# Altium schematic sheet ICWSHLP2_Adaptor_2x2_Connectors.SchDoc(ICWSHLP2_Adaptor_2x2_Connectors)
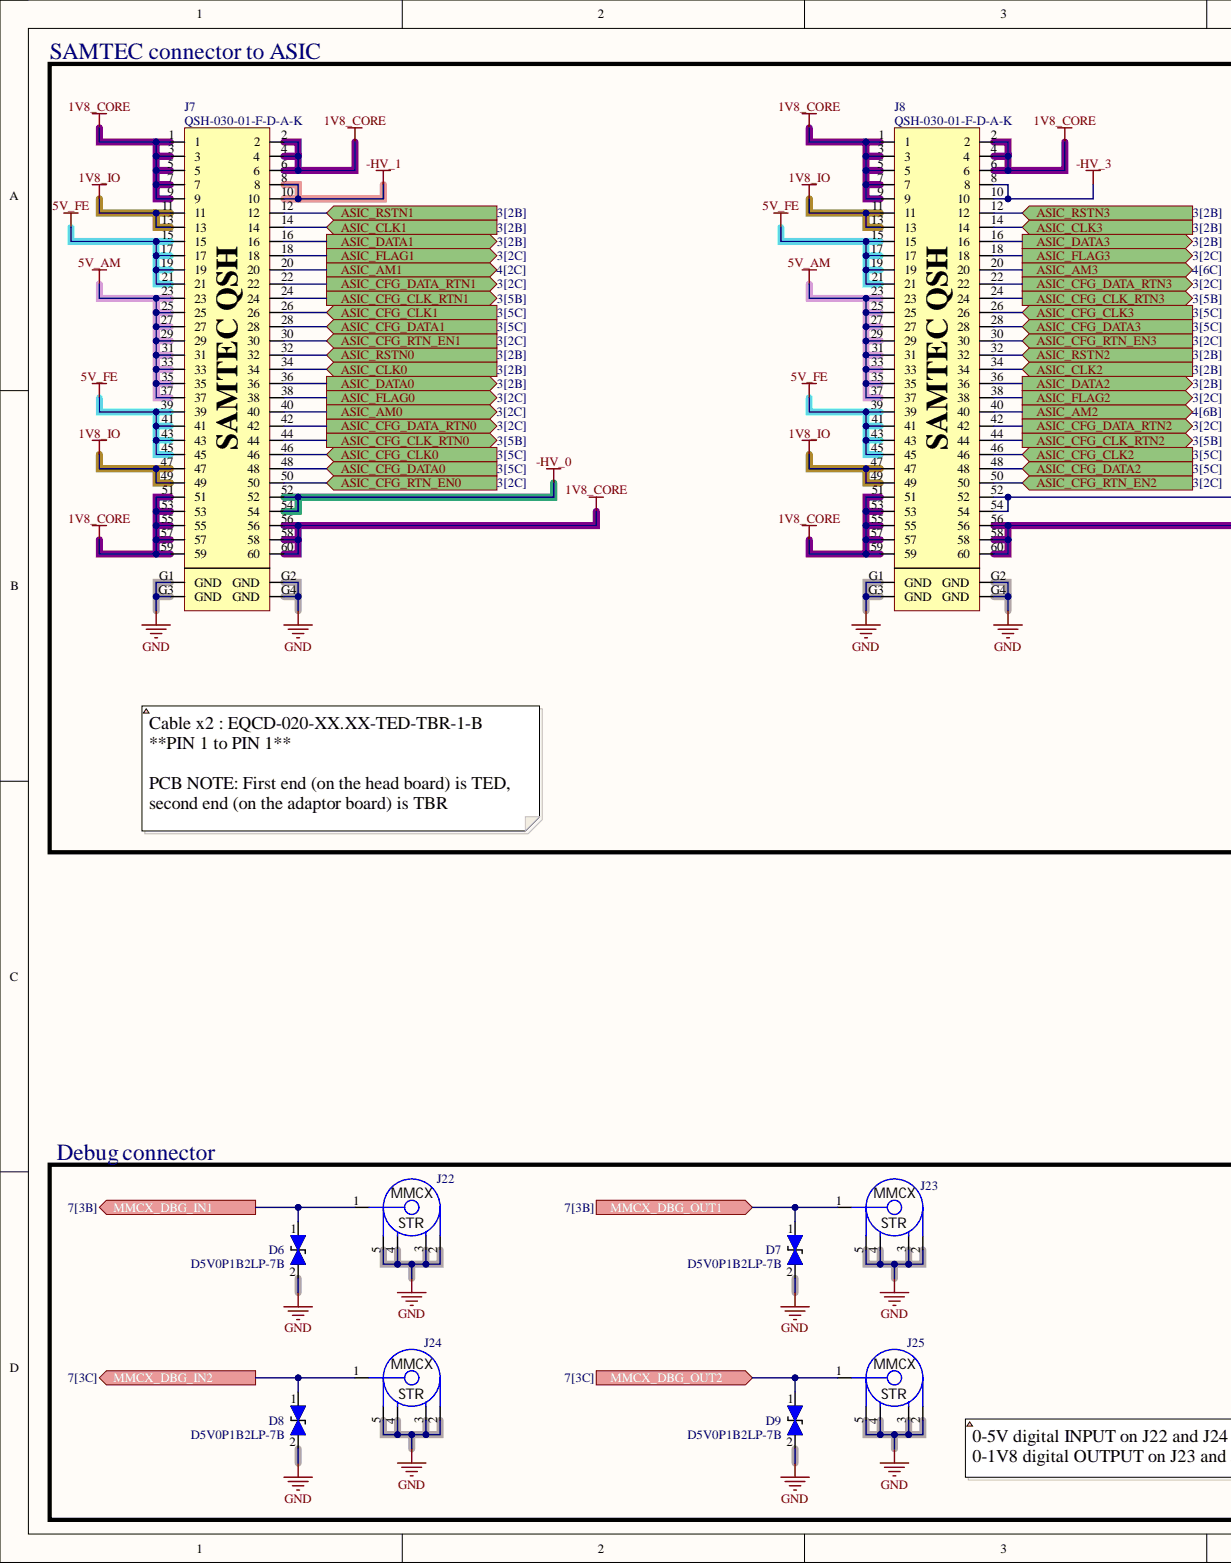
[diagram p0: ICWSHLP2_Adaptor_2x2_Connectors.SchDoc, left]
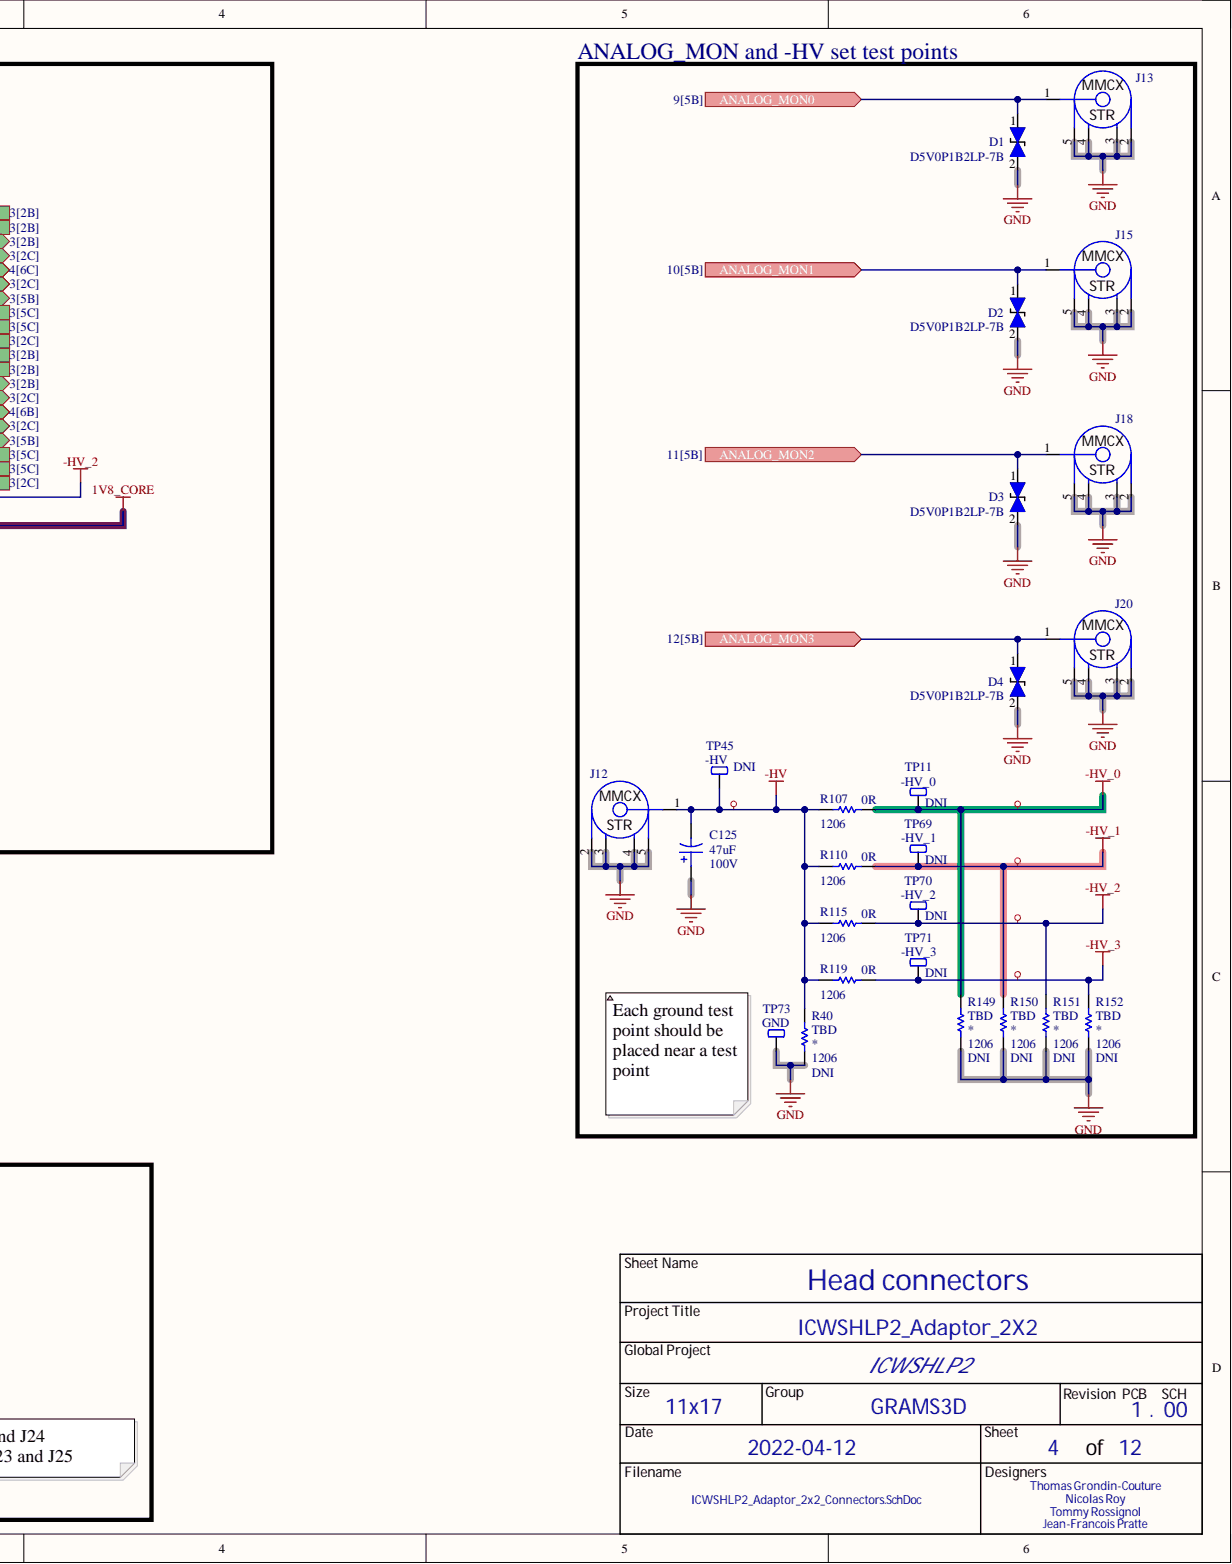
[diagram p1: ICWSHLP2_Adaptor_2x2_Connectors.SchDoc, right]

## Parts
C125  value 47uF  Voltage Rating: 100V  [CAP_ALU_870055975004]  (hidden comment: 870055975004)
D1  D5V0P1B2LP-7B  [TVS_DATA_D5V0P1B2LP-7B]
D2  D5V0P1B2LP-7B  [TVS_DATA_D5V0P1B2LP-7B]
D3  D5V0P1B2LP-7B  [TVS_DATA_D5V0P1B2LP-7B]
D4  D5V0P1B2LP-7B  [TVS_DATA_D5V0P1B2LP-7B]
D6  D5V0P1B2LP-7B  [TVS_DATA_D5V0P1B2LP-7B]
D7  D5V0P1B2LP-7B  [TVS_DATA_D5V0P1B2LP-7B]
D8  D5V0P1B2LP-7B  [TVS_DATA_D5V0P1B2LP-7B]
D9  D5V0P1B2LP-7B  [TVS_DATA_D5V0P1B2LP-7B]
J7  QSH-030-01-F-D-A-K  [CON_QSH-030-01-F-D-A-K]
J8  QSH-030-01-F-D-A-K  [CON_QSH-030-01-F-D-A-K]
J12  -HV  [CON_MMCX_STR_CONMMCX001]
J13  AM0  [CON_MMCX_STR_CONMMCX001]  (value not drawn)
J15  AM1  [CON_MMCX_STR_CONMMCX001]  (value not drawn)
J18  AM2  [CON_MMCX_STR_CONMMCX001]  (value not drawn)
J20  AM3  [CON_MMCX_STR_CONMMCX001]  (value not drawn)
J22  IN1  [CON_MMCX_STR_CONMMCX001]  (value not drawn)
J23  OUT1  [CON_MMCX_STR_CONMMCX001]  (value not drawn)
J24  IN2  [CON_MMCX_STR_CONMMCX001]  (value not drawn)
J25  OUT2  [CON_MMCX_STR_CONMMCX001]  (value not drawn)
R40  TBD  Assembly Note: DNI  Package: 1206  [RES_1206]
R107  value 0R  Package: 1206  [RES_1206]  (hidden comment: RC1206JR-070RL)
R110  value 0R  Package: 1206  [RES_1206]  (hidden comment: RC1206JR-070RL)
R115  value 0R  Package: 1206  [RES_1206]  (hidden comment: RC1206JR-070RL)
R119  value 0R  Package: 1206  [RES_1206]  (hidden comment: RC1206JR-070RL)
R149  TBD  Assembly Note: DNI  Package: 1206  [RES_1206]
R150  TBD  Assembly Note: DNI  Package: 1206  [RES_1206]
R151  TBD  Assembly Note: DNI  Package: 1206  [RES_1206]
R152  TBD  Assembly Note: DNI  Package: 1206  [RES_1206]
TP11  -HV_0  Assembly Note: DNI  [TPO_SMD_RCU-0C]
TP45  -HV  Assembly Note: DNI  [TPO_SMD_RCU-0C]
TP69  -HV_1  Assembly Note: DNI  [TPO_SMD_RCU-0C]
TP70  -HV_2  Assembly Note: DNI  [TPO_SMD_RCU-0C]
TP71  -HV_3  Assembly Note: DNI  [TPO_SMD_RCU-0C]
TP73  GND  [TPO_SMD_RCU-0C]

## Ports
ANALOG_MON0, ANALOG_MON1, ANALOG_MON2, ANALOG_MON3, ASIC_AM0, ASIC_AM1, ASIC_AM2, ASIC_AM3, ASIC_CFG_CLK0, ASIC_CFG_CLK1, ASIC_CFG_CLK2, ASIC_CFG_CLK3, ASIC_CFG_CLK_RTN0, ASIC_CFG_CLK_RTN1, ASIC_CFG_CLK_RTN2, ASIC_CFG_CLK_RTN3, ASIC_CFG_DATA0, ASIC_CFG_DATA1, ASIC_CFG_DATA2, ASIC_CFG_DATA3, ASIC_CFG_DATA_RTN0, ASIC_CFG_DATA_RTN1, ASIC_CFG_DATA_RTN2, ASIC_CFG_DATA_RTN3, ASIC_CFG_RTN_EN0, ASIC_CFG_RTN_EN1, ASIC_CFG_RTN_EN2, ASIC_CFG_RTN_EN3, ASIC_CLK0, ASIC_CLK1, ASIC_CLK2, ASIC_CLK3, ASIC_DATA0, ASIC_DATA1, ASIC_DATA2, ASIC_DATA3, ASIC_FLAG0, ASIC_FLAG1, ASIC_FLAG2, ASIC_FLAG3, ASIC_RSTN0, ASIC_RSTN1, ASIC_RSTN2, ASIC_RSTN3, MMCX_DBG_IN1, MMCX_DBG_IN2, MMCX_DBG_OUT1, MMCX_DBG_OUT2

## Nets
1V8_CORE: J7.1 J7.2 J7.3 J7.4 J7.5 J7.6 J7.7 J7.9 J7.51 J7.53 J7.55 J7.56 J7.57 J7.58 J7.59 J7.60 J8.1 J8.2 J8.3 J8.4 J8.5 J8.6 J8.7 J8.9 J8.51 J8.53 J8.55 J8.56 J8.57 J8.58 J8.59 J8.60
1V8_IO: J7.11 J7.13 J7.47 J7.49 J8.11 J8.13 J8.47 J8.49
5V_AM: J7.23 J7.25 J7.27 J7.29 J7.31 J7.33 J7.35 J7.37 J8.23 J8.25 J8.27 J8.29 J8.31 J8.33 J8.35 J8.37
5V_FE: J7.15 J7.17 J7.19 J7.21 J7.39 J7.41 J7.43 J7.45 J8.15 J8.17 J8.19 J8.21 J8.39 J8.41 J8.43 J8.45
-HV: C125.2 J12.1 R40.2 R107.1 R110.1 R115.1 R119.1 TP45.1
-HV_0: J7.52 J7.54 R107.2 R149.2 TP11.1
-HV_1: J7.8 J7.10 R110.2 R150.2 TP69.1
-HV_2: J8.52 J8.54 R115.2 R151.2 TP70.1
-HV_3: J8.8 J8.10 R119.2 R152.2 TP71.1
GND: C125.1 D1.2 D2.2 D3.2 D4.2 D6.2 D7.2 D8.2 D9.2 J7.G1(GND) J7.G2(GND) J7.G3(GND) J7.G4(GND) J8.G1(GND) J8.G2(GND) J8.G3(GND) J8.G4(GND) J12.2 J12.3 J12.4 J12.5 J13.2 J13.3 J13.4 J13.5 J15.2 J15.3 J15.4 J15.5 J18.2 J18.3 J18.4 J18.5 J20.2 J20.3 J20.4 J20.5 J22.2 J22.3 J22.4 J22.5 J23.2 J23.3 J23.4 J23.5 J24.2 J24.3 J24.4 J24.5 J25.2 J25.3 J25.4 J25.5 R40.1 R149.1 R150.1 R151.1 R152.1 TP73.1
ANALOG_MON0: D1.1 J13.1 ; port ANALOG_MON0
ANALOG_MON1: D2.1 J15.1 ; port ANALOG_MON1
ANALOG_MON2: D3.1 J18.1 ; port ANALOG_MON2
ANALOG_MON3: D4.1 J20.1 ; port ANALOG_MON3
ASIC_AM0: J7.40 ; port ASIC_AM0
ASIC_AM1: J7.20 ; port ASIC_AM1
ASIC_AM2: J8.40 ; port ASIC_AM2
ASIC_AM3: J8.20 ; port ASIC_AM3
ASIC_CFG_CLK0: J7.46 ; port ASIC_CFG_CLK0
ASIC_CFG_CLK1: J7.26 ; port ASIC_CFG_CLK1
ASIC_CFG_CLK2: J8.46 ; port ASIC_CFG_CLK2
ASIC_CFG_CLK3: J8.26 ; port ASIC_CFG_CLK3
ASIC_CFG_CLK_RTN0: J7.44 ; port ASIC_CFG_CLK_RTN0
ASIC_CFG_CLK_RTN1: J7.24 ; port ASIC_CFG_CLK_RTN1
ASIC_CFG_CLK_RTN2: J8.44 ; port ASIC_CFG_CLK_RTN2
ASIC_CFG_CLK_RTN3: J8.24 ; port ASIC_CFG_CLK_RTN3
ASIC_CFG_DATA0: J7.48 ; port ASIC_CFG_DATA0
ASIC_CFG_DATA1: J7.28 ; port ASIC_CFG_DATA1
ASIC_CFG_DATA2: J8.48 ; port ASIC_CFG_DATA2
ASIC_CFG_DATA3: J8.28 ; port ASIC_CFG_DATA3
ASIC_CFG_DATA_RTN0: J7.42 ; port ASIC_CFG_DATA_RTN0
ASIC_CFG_DATA_RTN1: J7.22 ; port ASIC_CFG_DATA_RTN1
ASIC_CFG_DATA_RTN2: J8.42 ; port ASIC_CFG_DATA_RTN2
ASIC_CFG_DATA_RTN3: J8.22 ; port ASIC_CFG_DATA_RTN3
ASIC_CFG_RTN_EN0: J7.50 ; port ASIC_CFG_RTN_EN0
ASIC_CFG_RTN_EN1: J7.30 ; port ASIC_CFG_RTN_EN1
ASIC_CFG_RTN_EN2: J8.50 ; port ASIC_CFG_RTN_EN2
ASIC_CFG_RTN_EN3: J8.30 ; port ASIC_CFG_RTN_EN3
ASIC_CLK0: J7.34 ; port ASIC_CLK0
ASIC_CLK1: J7.14 ; port ASIC_CLK1
ASIC_CLK2: J8.34 ; port ASIC_CLK2
ASIC_CLK3: J8.14 ; port ASIC_CLK3
ASIC_DATA0: J7.36 ; port ASIC_DATA0
ASIC_DATA1: J7.16 ; port ASIC_DATA1
ASIC_DATA2: J8.36 ; port ASIC_DATA2
ASIC_DATA3: J8.16 ; port ASIC_DATA3
ASIC_FLAG0: J7.38 ; port ASIC_FLAG0
ASIC_FLAG1: J7.18 ; port ASIC_FLAG1
ASIC_FLAG2: J8.38 ; port ASIC_FLAG2
ASIC_FLAG3: J8.18 ; port ASIC_FLAG3
ASIC_RSTN0: J7.32 ; port ASIC_RSTN0
ASIC_RSTN1: J7.12 ; port ASIC_RSTN1
ASIC_RSTN2: J8.32 ; port ASIC_RSTN2
ASIC_RSTN3: J8.12 ; port ASIC_RSTN3
MMCX_DBG_IN1: D6.1 J22.1 ; port MMCX_DBG_IN1
MMCX_DBG_IN2: D8.1 J24.1 ; port MMCX_DBG_IN2
MMCX_DBG_OUT1: D7.1 J23.1 ; port MMCX_DBG_OUT1
MMCX_DBG_OUT2: D9.1 J25.1 ; port MMCX_DBG_OUT2

## Library data of the parts
C125 = CAP_ALU_870055975004: CAP - ALUM POLY 47UF 20% 100V 50 mOhms - TH (10mm D x 14mm H); Manufacturer #1: Wurth Electronics Inc.; Cryo: UNKNOWN; Current Rating: 1.6A; GRAMS Lib Spec: 2.8; Polarized: Yes; Assembly Type: TH; Component Kind: Standard; HelpURL: https://katalog.we-online.de/pbs/datasheet/870055975004.pdf; Library Name: GRAMS_MASTER_LIB.IntLib; Magnetic Material: Unknown; Package: 10mm D x 14mm H; Part Number #1: 870055975004; Supplier 1: Digi-Key; Supplier Part Number 1: 732-11986-1-ND; Temperature: -55°C ~ 105°C; Tolerance: 20%; CreatedBy/Date: Keven Deslandes - 2018/10/18; VerifiedBy: Caroline Paulin - 18 janv 2019
D1-D4 D6-D9 = TVS_DATA_D5V0P1B2LP-7B: TVS - TVS DIODE 5.5V 13V - 0402; Manufacturer #1: Diodes Incorporated; Cryo: UNKNOWN; Current Rating: 4Apeak; GRAMS Lib Spec: 2.8; Polarized: Yes; Power Max: 250mW; Assembly Type: SMD; Component Kind: Standard; HelpURL: https://www.diodes.com/assets/Datasheets/D5V0P1B2LP.pdf; Library Name: GRAMS_MASTER_LIB.IntLib; Magnetic Material: Unknown; Package: 0402; Part Number #1: D5V0P1B2LP-7B; Supplier 1: Digi-Key; Supplier Part Number 1: D5V0P1B2LP-7BDICT-ND; Temperature: -65°C ~ 150°C (TJ); Voltage Rating: 5.5V; CreatedBy/Date: Keven Deslandes - 2018/10/19; VerifiedBy: Caroline Paulin -28 janvier 2018
J7 J8 = CON_QSH-030-01-F-D-A-K: CON - RCPT 0.5mm Q STRIP 30 POS SMD GOLD - 60 -SMD; Manufacturer #1: Samtec inc; Cryo: UNKNOWN; GRAMS Lib Spec: 3.2; Polarized: Yes; Solder Paste Type: TYPE 3; Stencil Thickness (Recommended): .152 mm; Value: 60-POS; Voltage Rating: 175VAC; Assembly Type: SMD; Component Kind: Standard; HelpURL: https://www.samtec.com/products/qsh; Library Name: GRAMS_MASTER_LIB_SCH.SchLib; Magnetic Material: UNKNOWN; Package: 60-SMD; Part Number #1: QSH-030-01-F-D-A-K; Part Number #2: -; Supplier 1: Samtec inc; Supplier Part Number 1: QSH-030-01-F-D-A-K; Temperature: -55 °C to +125 °C; CreatedBy/Date: Caroline Paulin - 01/11/2021; VerifiedBy: Phil M. 12/01/2022
J12 J13 J15 J18 J20 J22-J25 = CON_MMCX_STR_CONMMCX001: CON - MMCX female socket 50Ohm - TH -  Straight; Manufacturer #1: Linx Technologies Inc.; Cryo: Unknown; GRAMS Lib Spec: 2.9; Polarized: No; Value: 50 Ohm, 6 GHz; Voltage rating: 170V; Assembly Type: TH; Component Kind: Standard; HelpURL: https://linxtechnologies.com/wp/wp-content/uploads/conmmcx001.pdf; Library Name: GRAMS_MASTER_LIB.IntLib; Magnetic Material: Unknown; Note: Straight; Package: TH; Part Number #1: CONMMCX001; Supplier 1: Digi-Key; Supplier Part Number 1: CONMMCX001-ND; Temperature: -65°C ~ 165°C; CreatedBy/Date: Nicolas St-Jean 2020-07-27
R40 R149-R152 = RES_1206: RES - XXX  X% xW - 1206; Cryo: UNKNOWN; GRAMS Lib Spec: 2.8; Polarized: NO; Assembly Type: SMD; Component Kind: Standard; Library Name: GRAMS_MASTER_LIB.IntLib; Value: TBD; CreatedBy/Date: Danae Dufour-Forget/2019-05-31; VerifiedBy: C.Paulin - 3 juin 2019
R107 R110 R115 R119 = RES_RC1206JR-070RL: RES - 0R 1% 1/4W  - 1206; Manufacturer #1: Yageo; Cryo: UNKNOWN; Current Rating: 2A; GRAMS Lib Spec: 2.9; Polarized: No; Voltage Rating: 200V; Assembly Type: SMD; Component Kind: Standard; HelpURL: https://www.yageo.com/upload/media/product/productsearch/datasheet/rchip/PYu-RC_Group_51_RoHS_L_11.pdf; Library Name: GRAMS_MASTER_LIB.IntLib; Magnetic Material: Unknown; Part Number #1: RC1206JR-070RL; Power Max: 1/4W; Supplier 1: Digi-Key; Supplier Part Number 1: 311-0.0ERTR-ND; Temperature: -55°C ~ 155°C; CreatedBy/Date: Thomas Grondin-Couture 2020-09-28
TP11 TP45 TP69-TP71 TP73 = TPO_SMD_RCU-0C: TPO - TEST POINT PROBE PAD - 0603; Manufacturer #1: TE Connectivity AMP Connectors; Part Number #1: RCU-0C; Cryo: UNKNOWN; GRAMS Lib Spec: 2.8; Polarized: No; Value: 1.6 mm x 0.8 mm; Assembly Type: SMD; Component Kind: Standard; HelpURL: http://www.te.com/commerce/DocumentDelivery/DDEController?Action=srchrtrv&DocNm=1773266&DocType=DS&DocLang=English; Library Name: GRAMS_MASTER_LIB.IntLib; Magnetic Material: UNKNOWN; Package: 0603; Supplier 1: Digi-Key; Supplier Part Number 1: A106145CT-ND; CreatedBy/Date: Olivier Bernard / 20/5/2018; VerifiedBy: Caroline Paulin / 6 juillet 2018
